# Altium schematic sheet Sheet1.SchDoc
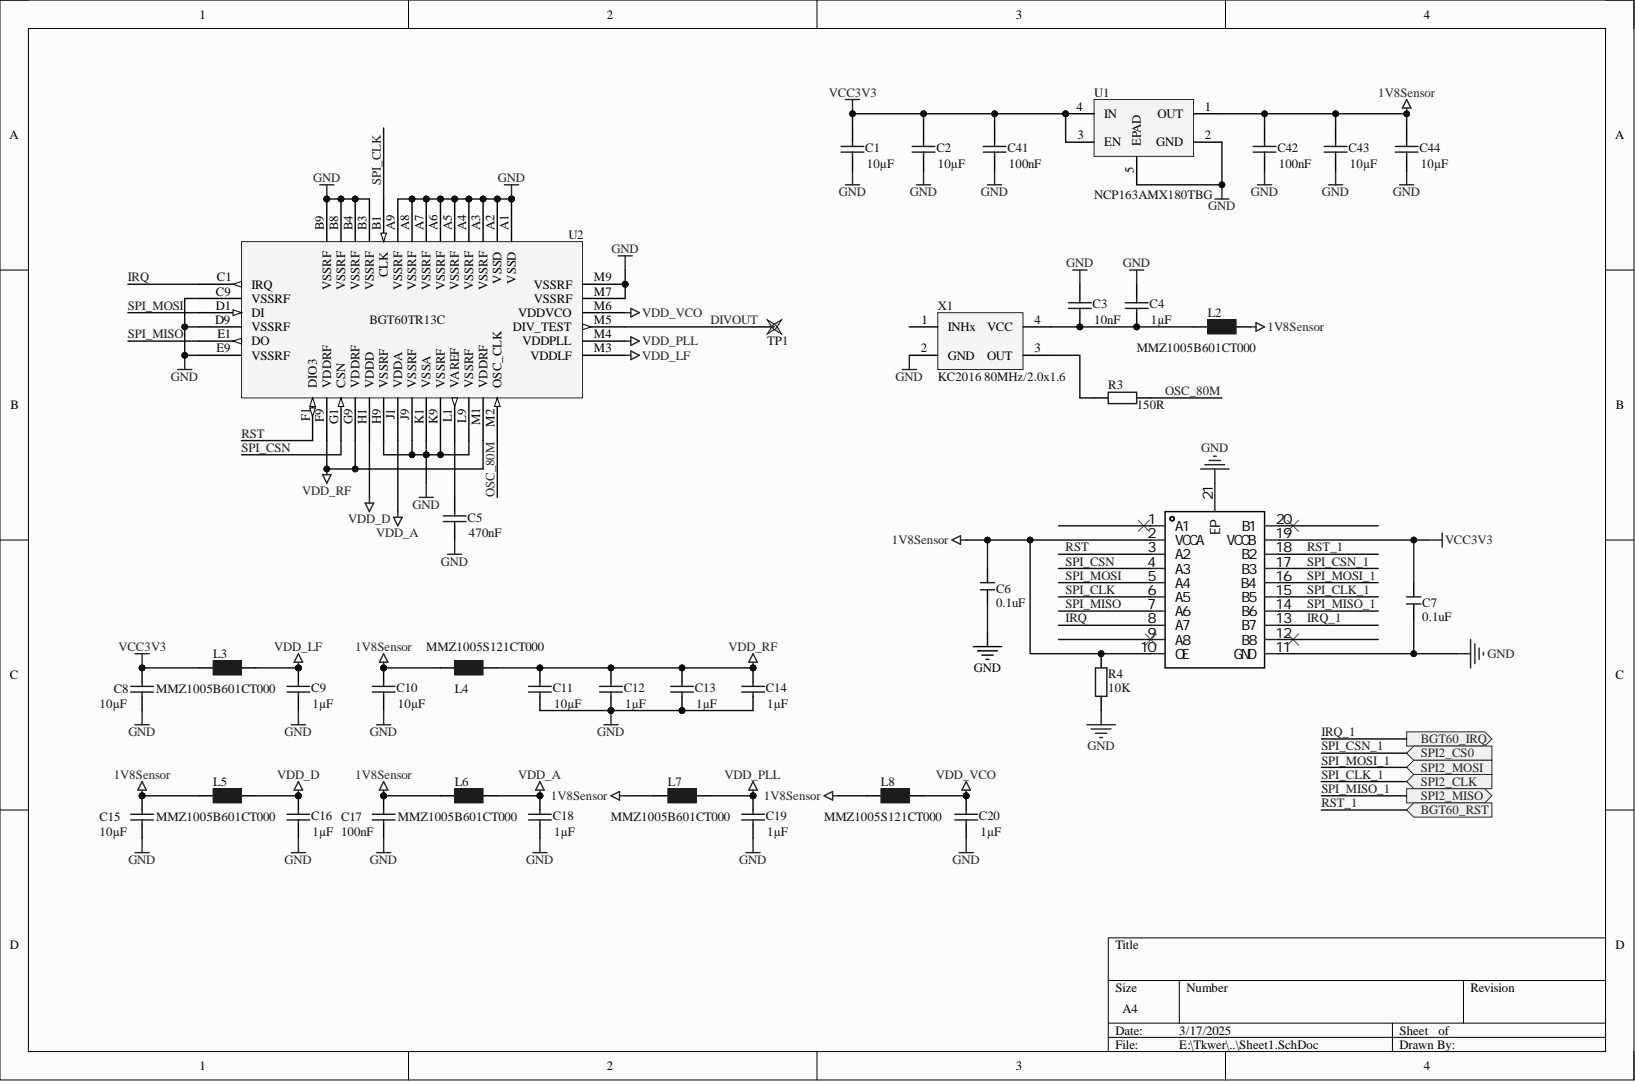
[diagram p0: Sheet1.SchDoc]

## Parts
C1  10µF  [0402_C_Axon]
C2  10µF  [0402_C_Axon]
C3  10nF  [0402_C_Axon]
C4  1µF  [0402_C_Axon]
C5  470nF  [0402_C_Axon]
C6  0.1uF  [C 0402_L]
C7  0.1uF  [C 0402_L]
C8  10µF  [0402_C_Axon]
C9  1µF  [0402_C_Axon]
C10  10µF  [0402_C_Axon]
C11  10µF  [0402_C_Axon]
C12  1µF  [0402_C_Axon]
C13  1µF  [0402_C_Axon]
C14  1µF  [0402_C_Axon]
C15  10µF  [0402_C_Axon]
C16  1µF  [0402_C_Axon]
C17  100nF  [0402_C_Axon]
C18  1µF  [0402_C_Axon]
C19  1µF  [0402_C_Axon]
C20  1µF  [0402_C_Axon]
C41  100nF  [0402_C_Axon]
C42  100nF  [0402_C_Axon]
C43  10µF  [0402_C_Axon]
C44  10µF  [0402_C_Axon]
L2  MMZ1005B601CT000  [0402_L_Axon]
L3  MMZ1005B601CT000  [0402_L_Axon]
L4  MMZ1005S121CT000  [0402_L_Axon]
L5  MMZ1005B601CT000  [0402_L_Axon]
L6  MMZ1005B601CT000  [0402_L_Axon]
L7  MMZ1005B601CT000  [0402_L_Axon]
L8  MMZ1005S121CT000  [0402_L_Axon]
R3  150R  [0402_R_Axon]
R4  10K  [R 0402_L]
TP1  Testpoint  [TP1.5MM]  (value not drawn)  (no BOM)
U1  NCP163AMX180TBG  [NCP163]
U2  BGT60TR13C  [BGT60TR13COriginal]
U3  TXB0108RGYR  [VQFN-20_L4.5-W3.5-P0.50-BL-EP1]  (value not drawn)
X1  KC2016 80MHz  value KC2016 80MHz/2.0x1.6  [X2016]

## Ports
BGT60_IRQ, BGT60_RST, SPI2_CLK, SPI2_CS0, SPI2_MISO, SPI2_MOSI

## Nets
1V8Sensor: C6.2 C10.2 C15.2 C17.2 C42.2 C43.2 C44.2 L2.2 L4.1 L5.1 L6.1 L7.1 L8.1 R4.1 U1.1(OUT) U3.2(VCCA) U3.10(OE)
GND: C1.1 C2.1 C3.2 C4.2 C5.1 C6.1 C7.1 C8.1 C9.1 C10.1 C11.1 C12.1 C13.1 C14.1 C15.1 C16.1 C17.1 C18.1 C19.1 C20.1 C41.1 C42.1 C43.1 C44.1 R4.2 U1.2(GND) U1.5(EPAD) U2.A1(VSSD) U2.A2(VSSD) U2.A3(VSSRF) U2.A4(VSSRF) U2.A5(VSSRF) U2.A6(VSSRF) U2.A7(VSSRF) U2.A8(VSSRF) U2.A9(VSSRF) U2.B3(VSSRF) U2.B4(VSSRF) U2.B8(VSSRF) U2.B9(VSSRF) U2.C9(VSSRF) U2.D9(VSSRF) U2.E9(VSSRF) U2.H9(VSSRF) U2.J9(VSSRF) U2.K1(VSSA) U2.K9(VSSRF) U2.L9(VSSRF) U2.M7(VSSRF) U2.M9(VSSRF) U3.11(GND) U3.21(EP) X1.2(GND)
VCC3V3: C1.2 C2.2 C7.2 C8.2 C41.2 L3.1 U1.3(EN) U1.4(IN) U3.19(VCCB)
VDD_A: C18.2 L6.2 U2.J1(VDDA)
VDD_D: C16.2 L5.2 U2.H1(VDDD)
VDD_LF: C9.2 L3.2 U2.M3(VDDLF)
VDD_PLL: C19.2 L7.2 U2.M4(VDDPLL)
VDD_RF: C11.2 C12.2 C13.2 C14.2 L4.2 U2.F9(VDDRF) U2.G9(VDDRF) U2.M1(VDDRF)
VDD_VCO: C20.2 L8.2 U2.M6(VDDVCO)
DIVOUT: TP1.1 U2.M5(DIV_TEST)
IRQ: U2.C1(IRQ) U3.8(A7)
IRQ_1: U3.13(B7) ; port BGT60_IRQ
OSC_80M: R3.2 U2.M2(OSC_CLK)
RST: U2.F1(DIO3) U3.3(A2)
RST_1: U3.18(B2) ; port BGT60_RST
SPI_CLK: U2.B1(CLK) U3.6(A5)
SPI_CLK_1: U3.15(B5) ; port SPI2_CLK
SPI_CSN: U2.G1(CSN) U3.4(A3)
SPI_CSN_1: U3.17(B3) ; port SPI2_CS0
SPI_MISO: U2.E1(DO) U3.7(A6)
SPI_MISO_1: U3.14(B6) ; port SPI2_MISO
SPI_MOSI: U2.D1(DI) U3.5(A4)
SPI_MOSI_1: U3.16(B4) ; port SPI2_MOSI
NetC3_1: C3.1 C4.1 L2.1 X1.4(VCC)
NetC5_2: C5.2 U2.L1(VAREF)
NetR3_1: R3.1 X1.3(OUT)

Not connected: U3 1(A1) 9(A8) 12(B8) 20(B1); X1 1(INHx)

## Library data of the parts
C1-C5 C8-C20 C41-C44 = Cap: Capacitor; Value: 0402; Component Kind: Standard; LatestRevisionDate: 17-Jul-2002; LatestRevisionNote: Re-released for DXP Platform.; Library Name: Miscellaneous Devices.IntLib; Published: 8-Jun-2000; Signal Integrity: Cap; Simulation: CAP; Publisher: Altium Limited
  C1 C2 C41-C44: PackageDescription: Radial Cap, Thru-Hole; 2 Leads; 0.3 in Pin Spacing; PackageReference: RAD-0.3
  C3-C5 C8-C20: PackageDescription: SMD 0402; PackageReference: 0402
C6 C7 = C: 无极性贴片电容; 版权归属: 源创客; BOM名称: 无极性贴片电容; Component Kind: Standard; Library Name: 3.1   - 电阻-电容-电感.SchLib; 学习资源: www.ooocke.com
L2-L8 = INDUCTOR1: SMD Ferrite Bead; Hersteller: Würth; Preis: 0; Value: 0402; Component Kind: Standard; Kleinmengendistributor: Digi-Key; Library Name: Tjuefire.SchLib
  L2 L3 L5-L7: FootprintBOM: 0402; KleinmengendistributorBestellnummer: 445-3337-1-ND
  L4 L8: FootprintBOM: 0805; KleinmengendistributorBestellnummer: 445-2160-1-ND
R3 = Res2: Resistor; Value: 0402; Component Kind: Standard; Library Name: Miscellaneous Devices.IntLib; Signal Integrity: Res; Simulation: RESISTOR
R4 = R: 贴片电阻; 版权归属: 源创客; BOM名称: 贴片电阻; Component Kind: Standard; Library Name: 3.1   - 电阻-电容-电感.SchLib; 学习资源: www.ooocke.com
TP1 = P_PRUEFPAD: Description: Testpoint; FootprintBOM: Testpoint; Preis: -; Library Name: Hatvan.SchLib
U1 = NCP177: NCP163 - LDO 250mA, XDFN4,  ultra-low noise, high PSRR; Component Kind: Standard; Library Name: Hatvan.SchLib
U2 = BGT60TR13COriginal: Infineon, 60GHz Radar Chip; Component Kind: Standard; Library Name: Hatvan.SchLib
U3 = TXB0108RGYR: 8通道 双向电平转换; Manufacturer: TI(德州仪器); Add into BOM: yes; Channel Type: 双向; Channels per Circuit: 8; Data Rate: 100Mbps; Number of Circuits: 1; Output Type: 三态，非反相; Supply Voltage (VCCA): 1.2V~3.6V; Supply Voltage (VCCB): 1.65V~5.5V; Translator Type: 电压电平; Component Kind: Standard; Convert to PCB: yes; Datasheet: https://atta.szlcsc.com/upload/public/pdf/source/20200527/C194701_912976D4DBA928C9D2968FAC041F03B8.pdf; Device: TXB0108RGYR; Features: 自动方向检测; Input Signal: -; JLCPCB Part Class: Extended Part; LCSC Part Name: TXB0108RGYR; Library Name: 1.1   - IC芯片.SchLib; Manufacturer Part: TXB0108RGYR; Name: TXB0108RGYR; Operating Temperature: -40℃~+85℃; Output Signal: -; Supplier: LCSC; Supplier Footprint: VQFN-20; Supplier Part: C194701; Symbol: TXB0108RGYR
X1 = XTAL-KC3224A80: XTAL, footprint from 2520 (backcompatibility); Manufacturer: Kyocera; Manufacturer Part Number: 581-KC2016K80.0C1GE; Component Kind: Standard; Distributor: Mouser; ManufacturerPartNo: 581-KC2016K80.0C1GE; OrderNr: 581-KC2016K80.0C1GE; PackageDescription: 2.0x1.6; PackageReference: 2.0x1.6; Part Number: 581-KC2016K80.0C1GE; Price: 1; Library Name: Hatvan.SchLib
